# Altium schematic sheet STM32C8.SchDoc
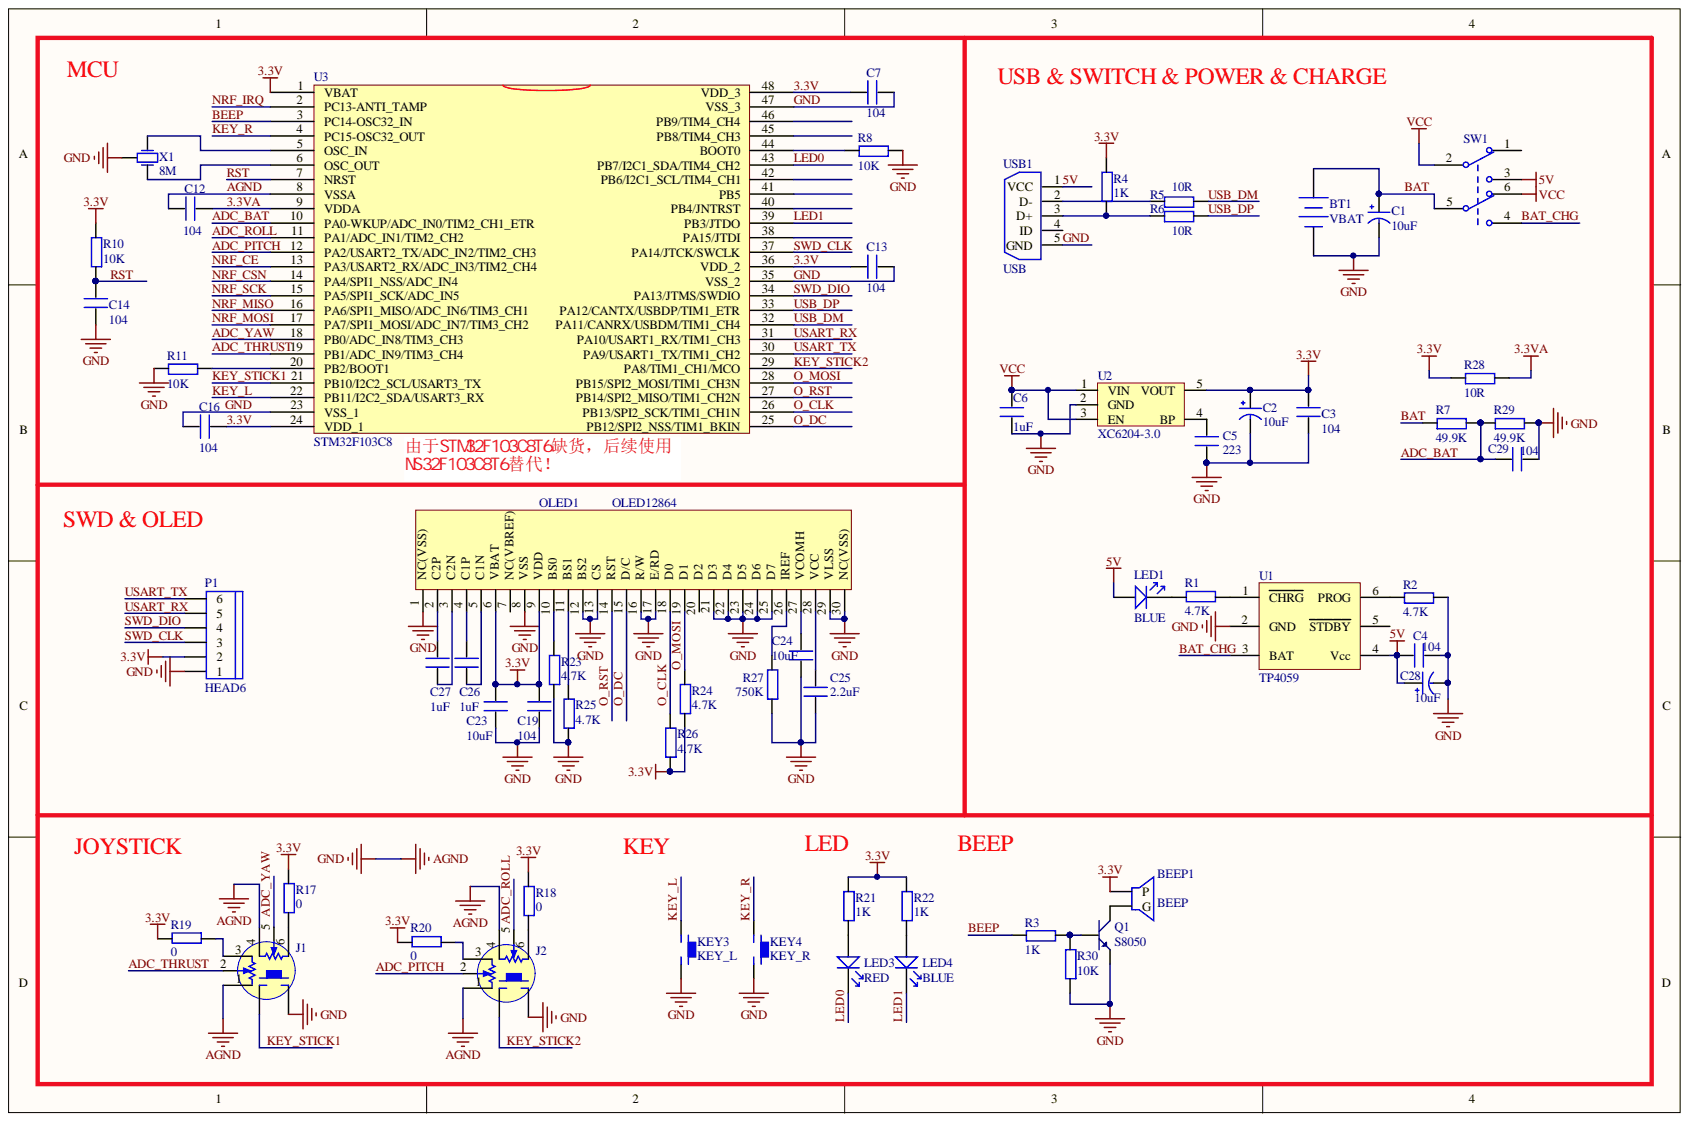
[diagram p0: STM32C8.SchDoc]

## Parts
BEEP1  BEEP  [BELL-5]
BT1  VBAT  [BAT-2]
C1  10uF  [C0805 钽电容]
C2  10uF  [C0805 钽电容]
C3  104  [0402]
C4  104  [0402]
C5  223  [0402]
C6  1uF  [0402]
C7  104  [0402]
C12  104  [0402]
C13  104  [0402]
C14  104  [0402]
C16  104  [0402]
C19  104  [0402]
C23  10uF  [0603P]
C24  10uF  [0603P]
C25  2.2uF  [0402]
C26  1uF  [0402]
C27  1uF  [0402]
C28  10uF  [C0805 钽电容]
C29  104  [0402]
J1  油门摇杆  [摇杆2]  (value not drawn)
J2  方向摇杆  [摇杆2]  (value not drawn)
KEY3  KEY_L  [KEY_M]
KEY4  KEY_R  [KEY_M]
LED1  BLUE  [0603]
LED3  RED  [0603]
LED4  BLUE  [0603]
OLED1  OLED12864  [OLED_0.96]
P1  HEAD6  [SH1.0-6P]
Q1  S8050  [SOT-23R]
R1  4.7K  [0402]
R2  4.7K  [0402]
R3  1K  [0402]
R4  1K  [0402]
R5  10R  [0402]
R6  10R  [0402]
R7  49.9K  [0402]
R8  10K  [0402]
R10  10K  [0402]
R11  10K  [0402]
R17  0  [0402]
R18  0  [0402]
R19  0  [0402]
R20  0  [0402]
R21  1K  [0402]
R22  1K  [0402]
R23  4.7K  [0402]
R24  4.7K  [0402]
R25  4.7K  [0402]
R26  4.7K  [0402]
R27  750K  [0402]
R28  10R  [0402]
R29  49.9K  [0402]
R30  10K  [0402]
SW1  单刀双掷  [拨动开关DIP]  (value not drawn)
U1  TP4059  [SOT-23-6]
U2  XC6204-3.0  [SOT-23-5]
U3  STM32F103C8  [mTQFP48]
USB1  USB  [MICRO_USB5P_SOP]
X1  8M  [XTAL3215]

## Nets
VCC: C6.2 SW1.2 SW1.6 U2.1(VIN) U2.3(EN)
3.3V: BEEP1.1 C2.1 C3.2 C7.2 C13.2 C16.2 C19.2 C23.1 OLED1.6(VBAT) OLED1.9(VDD) P1.2 R4.2 R10.2 R17.2 R18.2 R19.2 R20.2 R21.2 R22.2 R24.1 R26.1 R28.1 U2.5(VOUT) U3.1(VBAT) U3.24(VDD_1) U3.36(VDD_2) U3.48(VDD_3)
3.3VA: C12.1 R28.2 U3.9(VDDA)
5V: C4.2 C28.1 LED1.1 SW1.3 U1.4(Vcc) USB1.1(VCC)
ADC_BAT: C29.2 R7.2 R29.1 U3.10(PA0-WKUP/ADC_IN0/TIM2_CH1_ETR)
ADC_PITCH: J2.2 U3.12(PA2/USART2_TX/ADC_IN2/TIM2_CH3)
ADC_ROLL: J2.5 U3.11(PA1/ADC_IN1/TIM2_CH2)
ADC_THRUST: J1.2 U3.19(PB1/ADC_IN9/TIM3_CH4)
ADC_YAW: J1.5 U3.18(PB0/ADC_IN8/TIM3_CH3)
AGND: BT1.2 C1.2 C2.2 C3.1 C4.1 C5.1 C6.1 C7.1 C12.2 C13.1 C14.1 C16.1 C19.1 C23.2 C24.2 C25.2 C28.2 C29.1 J1.1 J1.4 J1.J1 J2.1 J2.4 J2.J1 KEY3.2 KEY4.2 OLED1.1(NC(VSS)) OLED1.8(VSS) OLED1.12(BS2) OLED1.13(CS) OLED1.16(R/W) OLED1.17(E/RD) OLED1.21(D3) OLED1.22(D4) OLED1.23(D5) OLED1.24(D6) OLED1.25(D7) OLED1.29(VLSS) OLED1.30(NC(VSS)) P1.1 Q1.1 R2.2 R8.2 R11.1 R23.1 R25.1 R27.1 R29.2 R30.1 U1.2(GND) U2.2(GND) U3.8(VSSA) U3.23(VSS_1) U3.35(VSS_2) U3.47(VSS_3) USB1.5(GND) X1.2
BAT: BT1.1 C1.1 R7.1 SW1.5
BAT_CHG: SW1.4 U1.3(BAT)
BEEP: R3.1 U3.3(PC14-OSC32_IN)
KEY_L: KEY3.1 U3.22(PB11/I2C2_SDA/USART3_RX)
KEY_R: KEY4.1 U3.4(PC15-OSC32_OUT)
KEY_STICK1: J1.J2 U3.21(PB10/I2C2_SCL/USART3_TX)
KEY_STICK2: J2.J2 U3.29(PA8/TIM1_CH1/MCO)
LED0: LED3.2 U3.43(PB7/I2C1_SDA/TIM4_CH2)
LED1: LED4.2 U3.39(PB3/JTDO)
NRF_CE: U3.13(PA3/USART2_RX/ADC_IN3/TIM2_CH4)
NRF_CSN: U3.14(PA4/SPI1_NSS/ADC_IN4)
NRF_IRQ: U3.2(PC13-ANTI_TAMP)
NRF_MISO: U3.16(PA6/SPI1_MISO/ADC_IN6/TIM3_CH1)
NRF_MOSI: U3.17(PA7/SPI1_MOSI/ADC_IN7/TIM3_CH2)
NRF_SCK: U3.15(PA5/SPI1_SCK/ADC_IN5)
O_CLK: OLED1.18(D0) R26.2 U3.26(PB13/SPI2_SCK/TIM1_CH1N)
O_DC: OLED1.15(D/C) U3.25(PB12/SPI2_NSS/TIM1_BKIN)
O_MOSI: OLED1.19(D1) R24.2 U3.28(PB15/SPI2_MOSI/TIM1_CH3N)
O_RST: OLED1.14(RST) U3.27(PB14/SPI2_MISO/TIM1_CH2N)
RST: C14.2 R10.1 U3.7(NRST)
SWD_CLK: P1.3 U3.37(PA14/JTCK/SWCLK)
SWD_DIO: P1.4 U3.34(PA13/JTMS/SWDIO)
USART_RX: P1.5 U3.31(PA10/USART1_RX/TIM1_CH3)
USART_TX: P1.6 U3.30(PA9/USART1_TX/TIM1_CH2)
USB_DM: R5.2 U3.32(PA11/CANRX/USBDM/TIM1_CH4)
USB_DP: R6.2 U3.33(PA12/CANTX/USBDP/TIM1_ETR)
NetBEEP1_2: BEEP1.2 Q1.3
NetC5_2: C5.2 U2.4(BP)
NetC24_1: C24.1 OLED1.27(VCOMH)
NetC25_1: C25.1 OLED1.28(VCC)
NetC26_1: C26.1 OLED1.5(C1N)
NetC26_2: C26.2 OLED1.4(C1P)
NetC27_1: C27.1 OLED1.3(C2N)
NetC27_2: C27.2 OLED1.2(C2P)
NetJ1_3: J1.3 R19.1
NetJ1_6: J1.6 R17.1
NetJ2_3: J2.3 R20.1
NetJ2_6: J2.6 R18.1
NetLED1_2: LED1.2 R1.2
NetLED3_1: LED3.1 R21.1
NetLED4_1: LED4.1 R22.1
NetOLED1_10: OLED1.10(BS0) R23.2
NetOLED1_11: OLED1.11(BS1) R25.2
NetOLED1_26: OLED1.26(IREF) R27.2
NetQ1_2: Q1.2 R3.2 R30.2
NetR1_1: R1.1 U1.1(CHRG)
NetR2_1: R2.1 U1.6(PROG)
NetR4_1: R4.1 R6.1 USB1.3(D+)
NetR5_1: R5.1 USB1.2(D-)
NetR8_1: R8.1 U3.44(BOOT0)
NetR11_2: R11.2 U3.20(PB2/BOOT1)
NetU3_5: U3.5(OSC_IN) X1.1
NetU3_6: U3.6(OSC_OUT) X1.3

Not connected: OLED1 7(NC(VBREF)) 20(D2); SW1 1; U1 5(STDBY); U3 38(PA15/JTDI) 40(PB4/JNTRST) 41(PB5) 42(PB6/I2C1_SCL/TIM4_CH1) 45(PB8/TIM4_CH3) 46(PB9/TIM4_CH4); USB1 4(ID)

## Library data of the parts
BT1 = Battery: Multicell Battery; Component Kind: Standard; LatestRevisionDate: 17-Jul-2002; LatestRevisionNote: Re-released for DXP Platform.; Library Name: Miscellaneous Devices.IntLib; PackageReference: BAT-2; Published: 24-Mar-1999; Publisher: Altium Limited
C1 C2 C28 = CAP: Component Kind: Standard; Library Name: ATK-2014-09-12.SchLib
C3-C7 C12-C14 C16 C19 C23-C27 C29 = C: Component Kind: Standard; Library Name: ATK-2014-09-12.SchLib
J1 J2 = 摇杆: Component Kind: Standard; Description: 摇杆; Library Name: Schlib1.SchLib
KEY3 KEY4 = KEY: Component Kind: Standard; Library Name: ATK-2014-09-12.SchLib
LED1 LED3 LED4 = LED: Component Kind: Standard; Library Name: ATK-2014-09-12.SchLib
Q1 = NPN: 8050/BCW846/BCW847; Component Kind: Standard; Library Name: ATK-2014-09-12.SchLib
R1-R8 R10 R11 R17-R30 = R: Component Kind: Standard; Library Name: ATK-2014-09-12.SchLib
SW1 = 单刀双掷开关: Component Kind: Standard; Description: 单刀双掷开关; Library Name: Schlib1.SchLib
U1 = TP2459: 600mA充电芯片; Component Kind: Standard; Library Name: ATK-2014-09-12.SchLib
U2 = RT9193-33: 3.3V超低压差LDO; Component Kind: Standard; Library Name: ATK-2014-09-12.SchLib
U3 = STM32F103C6: Component Kind: Standard; Library Name: ATK-2014-09-12.SchLib
USB1 = USB5: Component Kind: Standard; Library Name: ATK-2014-09-12.SchLib
X1 = XTAL-3215: Component Kind: Standard; Library Name: ATK-2014-09-12.SchLib
BEEP1 = BEEP: Component Kind: Standard; Library Name: ATK-2014-09-12.SchLib
OLED1 = OLED12864: Component Kind: Standard; Library Name: ATK-2014-09-12.SchLib
P1 = HEAD6: Component Kind: Standard; Library Name: ATK-2014-09-12.SchLib
